# Altium schematic sheet 0_Overview.SchDoc
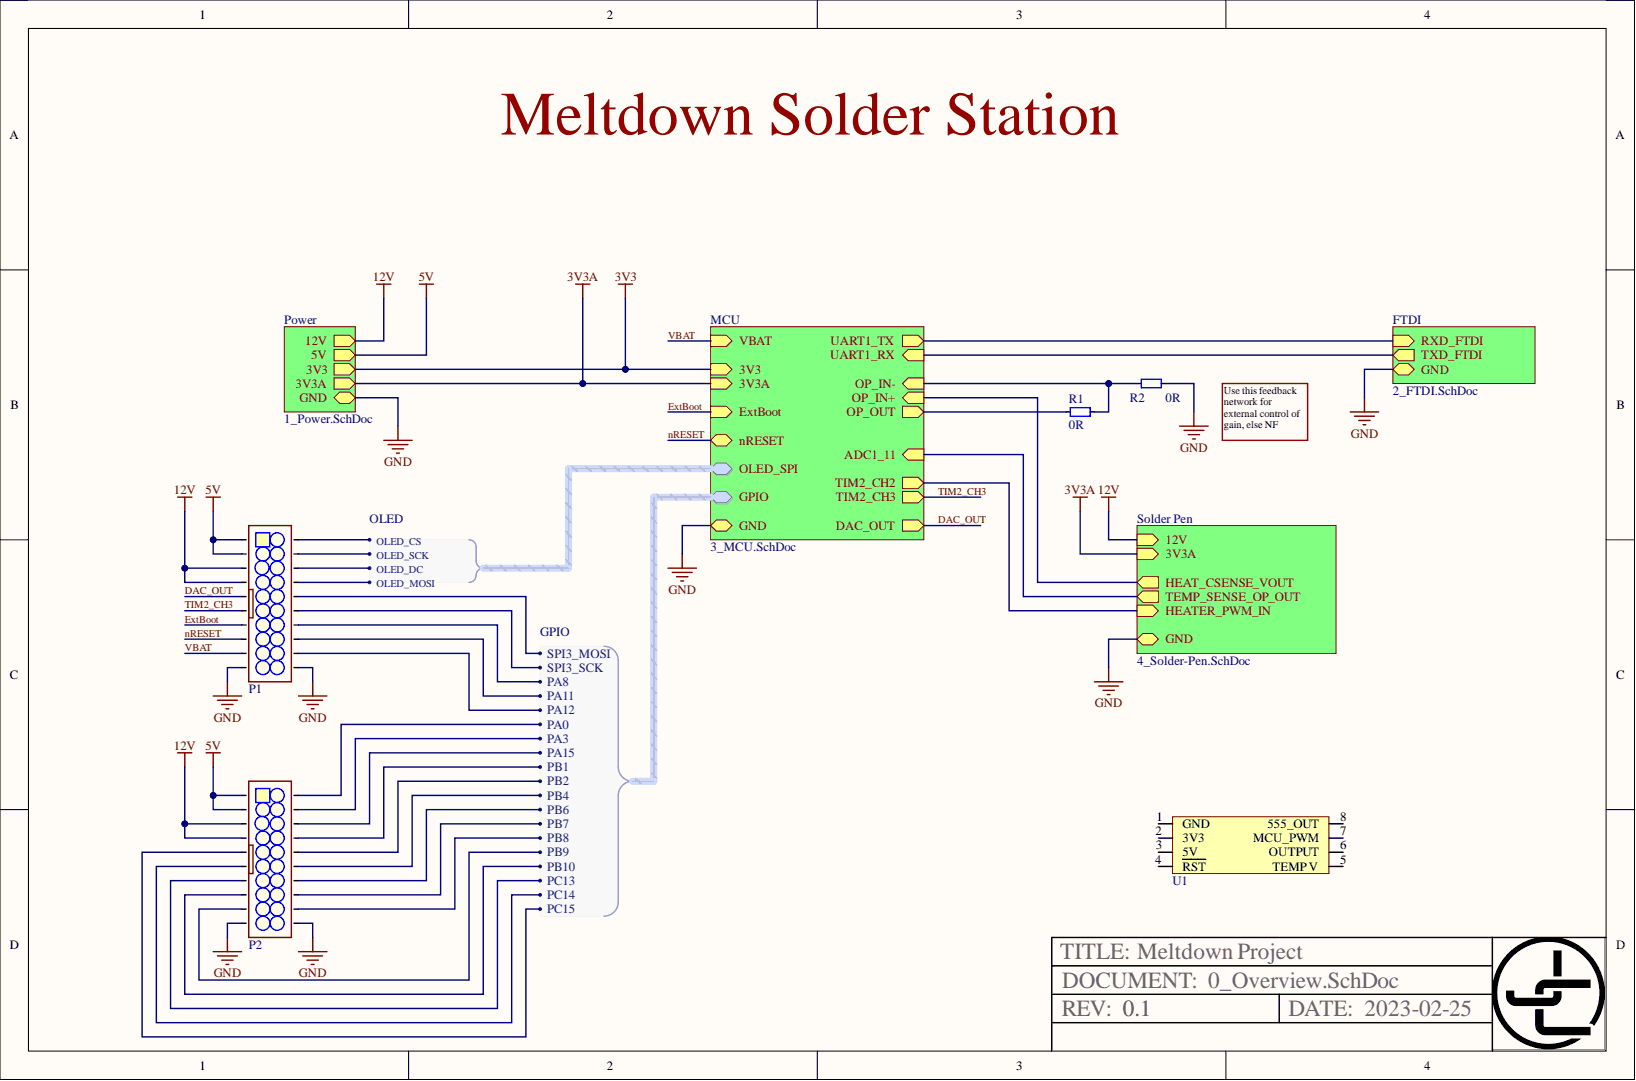
[diagram p0: 0_Overview.SchDoc]

## Parts
P1  2x10 Ribbon Header  [2x10_RIBBON_CABLE_CON]  (value not drawn)
P2  2x10 Ribbon Header  [2x10_RIBBON_CABLE_CON]  (value not drawn)
R1  value 0R  [0603]  (hidden comment: 0603, resistor)
R2  value 0R  [0603]  (hidden comment: 0603, resistor)
U1  Meltdown Protection Module  [MELTDOWN_PROT]  (value not drawn)

## Nets
5V: P1.1 P1.2 P2.1 P2.2
12V: P1.3 P1.4 P2.3 P2.4
GND: P1.10 P1.11 P2.10 P2.11 R2.0
DAC_OUT: P1.5
ExtBoot: P1.7
nRESET: P1.8
TIM2_CH3: P1.6
VBAT: P1.9
NetP1_12: P1.12
NetP1_13: P1.13
NetP1_14: P1.14
NetP1_15: P1.15
NetP1_16: P1.16
NetP1_17: P1.17
NetP1_18: P1.18
NetP1_19: P1.19
NetP1_20: P1.20
NetP2_5: P2.5
NetP2_6: P2.6
NetP2_7: P2.7
NetP2_8: P2.8
NetP2_9: P2.9
NetP2_12: P2.12
NetP2_13: P2.13
NetP2_14: P2.14
NetP2_15: P2.15
NetP2_16: P2.16
NetP2_17: P2.17
NetP2_18: P2.18
NetP2_19: P2.19
NetP2_20: P2.20
NetR1_0: R1.0
NetR1_1: R1.1 R2.1
NetU1_1: U1.1(GND)
NetU1_2: U1.2(3V3)
NetU1_3: U1.3(5V)
NetU1_4: U1.4(RST)
NetU1_5: U1.5(TEMP_V)
NetU1_6: U1.6(OUTPUT)
NetU1_7: U1.7(MCU_PWM)
NetU1_8: U1.8(555_OUT)
3V3:
3V3A:
ADC1_11:
HEAT_CSENSE_VOUT:
HEATER_PWM_IN:
RXD_FTDI:
TXD_FTDI:

## Library data of the parts
P1 P2 = 2x10 Ribbon Header: 2.54 mm; Value: 1x10 Pin Header; Component Kind: Standard; Library Name: jclib.IntLib
U1 = Meltdown Protection Module: Protection circuit for the meltdown project; Component Kind: Standard; Library Name: jclib.IntLib
R1 R2 = 0603, resistor: Component Kind: Standard; Library Name: jclib.IntLib
